# Altium schematic sheet 7SEG.SchDoc
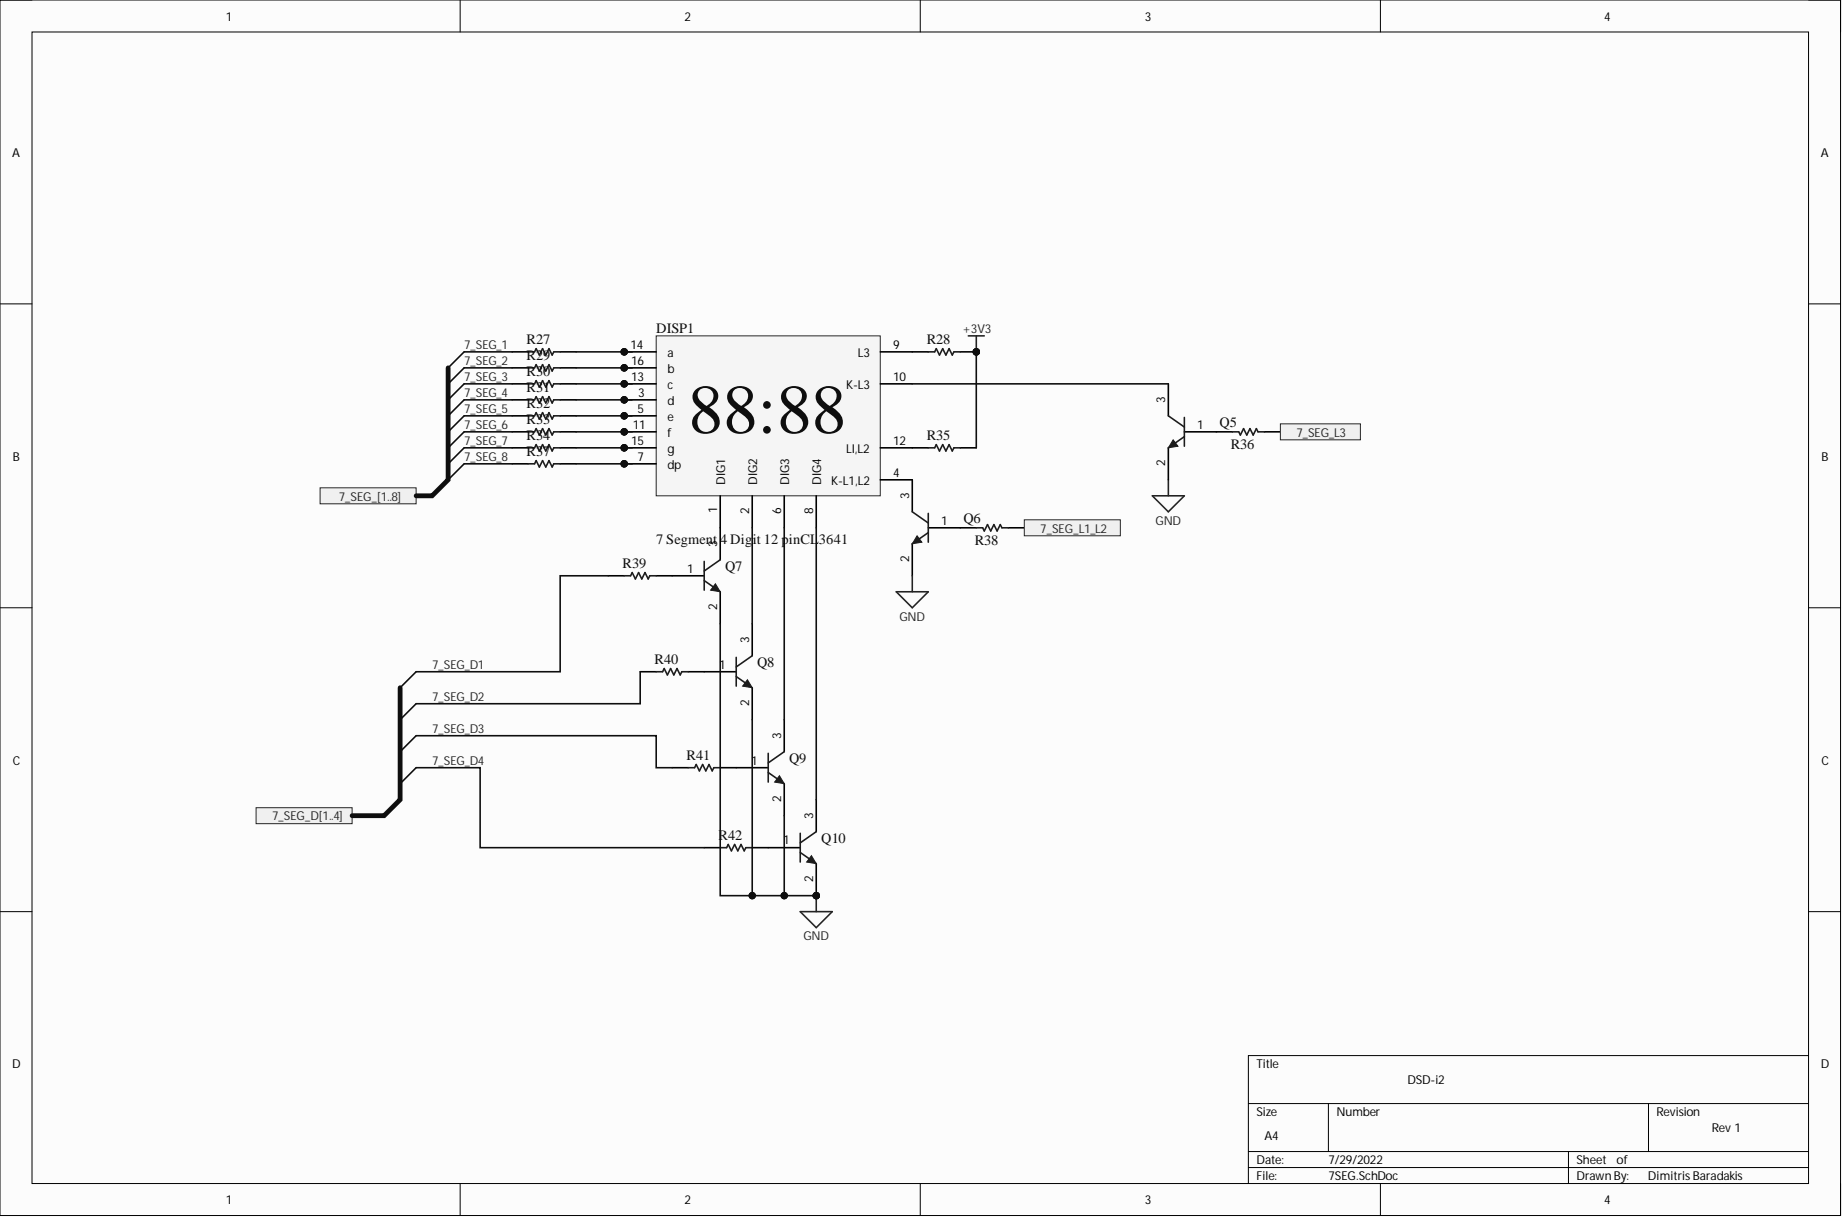
[diagram p0: 7SEG.SchDoc]

## Parts
DISP1  7 Segment 4 Digit 12 pinCL3641  [PCB-2108ea764ac7fecf-1]
Q5  BC848BLT3G  [ONSC-SOT-23-3-318-08_V]  (value not drawn)
Q6  BC848BLT3G  [ONSC-SOT-23-3-318-08_V]  (value not drawn)
Q7  BC848BLT3G  [ONSC-SOT-23-3-318-08_V]  (value not drawn)
Q8  BC848BLT3G  [ONSC-SOT-23-3-318-08_V]  (value not drawn)
Q9  BC848BLT3G  [ONSC-SOT-23-3-318-08_V]  (value not drawn)
Q10  BC848BLT3G  [ONSC-SOT-23-3-318-08_V]  (value not drawn)
R27  Panasonic ERJ-1GNF1620C  [Panasonic ERJ-1GNF1620C-Footprint-1]  (value not drawn)
R28  Panasonic ERJ-1GNF1620C  [Panasonic ERJ-1GNF1620C-Footprint-1]  (value not drawn)
R29  Panasonic ERJ-1GNF1620C  [Panasonic ERJ-1GNF1620C-Footprint-1]  (value not drawn)
R30  Panasonic ERJ-1GNF1620C  [Panasonic ERJ-1GNF1620C-Footprint-1]  (value not drawn)
R31  Panasonic ERJ-1GNF1620C  [Panasonic ERJ-1GNF1620C-Footprint-1]  (value not drawn)
R32  Panasonic ERJ-1GNF1620C  [Panasonic ERJ-1GNF1620C-Footprint-1]  (value not drawn)
R33  Panasonic ERJ-1GNF1620C  [Panasonic ERJ-1GNF1620C-Footprint-1]  (value not drawn)
R34  Panasonic ERJ-1GNF1620C  [Panasonic ERJ-1GNF1620C-Footprint-1]  (value not drawn)
R35  Panasonic ERJ-1GNF1620C  [Panasonic ERJ-1GNF1620C-Footprint-1]  (value not drawn)
R36  Bourns CR0201AJW-103GLF  [Bourns CR0201AJW-103GLF-Footprint-1]  (value not drawn)
R37  Panasonic ERJ-1GNF1620C  [Panasonic ERJ-1GNF1620C-Footprint-1]  (value not drawn)
R38  Bourns CR0201AJW-103GLF  [Bourns CR0201AJW-103GLF-Footprint-1]  (value not drawn)
R39  Bourns CR0201AJW-103GLF  [Bourns CR0201AJW-103GLF-Footprint-1]  (value not drawn)
R40  Bourns CR0201AJW-103GLF  [Bourns CR0201AJW-103GLF-Footprint-1]  (value not drawn)
R41  Bourns CR0201AJW-103GLF  [Bourns CR0201AJW-103GLF-Footprint-1]  (value not drawn)
R42  Bourns CR0201AJW-103GLF  [Bourns CR0201AJW-103GLF-Footprint-1]  (value not drawn)

## Ports
7_SEG_1, 7_SEG_2, 7_SEG_3, 7_SEG_4, 7_SEG_5, 7_SEG_6, 7_SEG_7, 7_SEG_8, 7_SEG_[1..8], 7_SEG_D1, 7_SEG_D2, 7_SEG_D3, 7_SEG_D4, 7_SEG_D[1..4], 7_SEG_L1_L2, 7_SEG_L3

## Nets
+3V3: R28.2 R35.2
GND: Q5.2 Q6.2 Q7.2 Q8.2 Q9.2 Q10.2
7_SEG_1: R27.1 ; port 7_SEG_[1..8]  [also labelled ]
7_SEG_2: R29.1 ; port 7_SEG_[1..8]  [also labelled ]
7_SEG_3: R30.1 ; port 7_SEG_[1..8]  [also labelled ]
7_SEG_4: R31.1 ; port 7_SEG_[1..8]  [also labelled ]
7_SEG_5: R32.1 ; port 7_SEG_[1..8]  [also labelled ]
7_SEG_6: R33.1 ; port 7_SEG_[1..8]  [also labelled ]
7_SEG_7: R34.1 ; port 7_SEG_[1..8]  [also labelled ]
7_SEG_8: R37.1 ; port 7_SEG_[1..8]  [also labelled ]
7_SEG_D1: R39.1 ; port 7_SEG_D[1..4]  [also labelled ]
7_SEG_D2: R40.1 ; port 7_SEG_D[1..4]  [also labelled ]
7_SEG_D3: R41.1 ; port 7_SEG_D[1..4]  [also labelled ]
7_SEG_D4: R42.1 ; port 7_SEG_D[1..4]  [also labelled ]
7_SEG_L1_L2: R38.1 ; port 7_SEG_L1_L2
7_SEG_L3: R36.1 ; port 7_SEG_L3
NetDISP1_1: DISP1.1(DIG1) Q7.3
NetDISP1_2: DISP1.2(DIG2) Q8.3
NetDISP1_3: DISP1.3(d) R31.2
NetDISP1_4: DISP1.4(K-L1,L2) Q6.3
NetDISP1_5: DISP1.5(e) R32.2
NetDISP1_6: DISP1.6(DIG3) Q9.3
NetDISP1_7: DISP1.7(dp) R37.2
NetDISP1_8: DISP1.8(DIG4) Q10.3
NetDISP1_9: DISP1.9(L3) R28.1
NetDISP1_10: DISP1.10(K-L3) Q5.3
NetDISP1_11: DISP1.11(f) R33.2
NetDISP1_12: DISP1.12(LI,L2) R35.1
NetDISP1_13: DISP1.13(c) R30.2
NetDISP1_14: DISP1.14(a) R27.2
NetDISP1_15: DISP1.15(g) R34.2
NetDISP1_16: DISP1.16(b) R29.2
NetQ5_1: Q5.1 R36.2
NetQ6_1: Q6.1 R38.2
NetQ7_1: Q7.1 R39.2
NetQ8_1: Q8.1 R40.2
NetQ9_1: Q9.1 R41.2
NetQ10_1: Q10.1 R42.2

## Library data of the parts
DISP1 = CMP-2108ea764ac7fecf-13: 4 Digit 7-Segment LED Display; Manufacturer Part Number: TDCG1060M; Character Size-Height: 10.0 mm; Forward Voltage: 2.40 V; Lead-Free Status: Lead Free; Luminous Intensity: 4.00 mcd; Color: Green; Component Kind: Standard; Library Name: My Personal Space; Mounting Style: Through Hole; Octopart URL: https://octopart.com/tdcg1060m-vishay-41176745; OctopartID: 2108ea764ac7fecf; RoHS: Compliant
Q5-Q10 = CMP-860696-3: General Purpose Transistor, NPN Silicon, 3-Pin SOT-23, Pb-Free, Tape and Reel; Current Rating: 0.1 A; Lead-Free Status: Lead Free; Voltage Rating (DC): 30.0 V; Case/Package: SOT-23; Component Kind: Standard; Library Name: My Personal Space; Lifecycle Status: Active; Manufacturer Part Number: BC848BLT3G; Mounting Style: Surface Mount; Number of Pins: 3; Octopart Url: https://octopart.com/bc848blt3g-on+semiconductor-835077; OctopartID: 31b1f8b7aad2ee76; Packaging: Tape; Polarity: NPN; RoHS: Compliant
R27-R35 R37 = CMP-3673637-4: Thick Film Resistors 0201 162ohm 1% Halogen Free; Power Rating: 0.05 W; Resistance: 162.0 Ω; Case/Package: 0201; Component Kind: Standard; Library Name: My Personal Space; Lifecycle Status: Not Listed by Manufacturer; Manufacturer Part Number: ERJ-1GNF1620C; Octopart Url: https://octopart.com/erj-1gnf1620c-panasonic-44402935; OctopartID: 2ca1e70e8130f366; Resistance Tolerance: ±1%; RoHS: Compliant
R36 R38-R42 = CMP-0c15c370377fd3d2-2: Thick Film Chip Resistor; 0201 10k 5% 50mW TC200; Component Kind: Standard; Library Name: My Personal Space; Manufacturer Part Number: CR0201AJW-103GLF; Octopart Url: https://octopart.com/cr0201ajw-103glf-bourns-107945484; OctopartID: 0c15c370377fd3d2
